# Altium schematic sheet Remote Board.SchDoc("Remote Board")
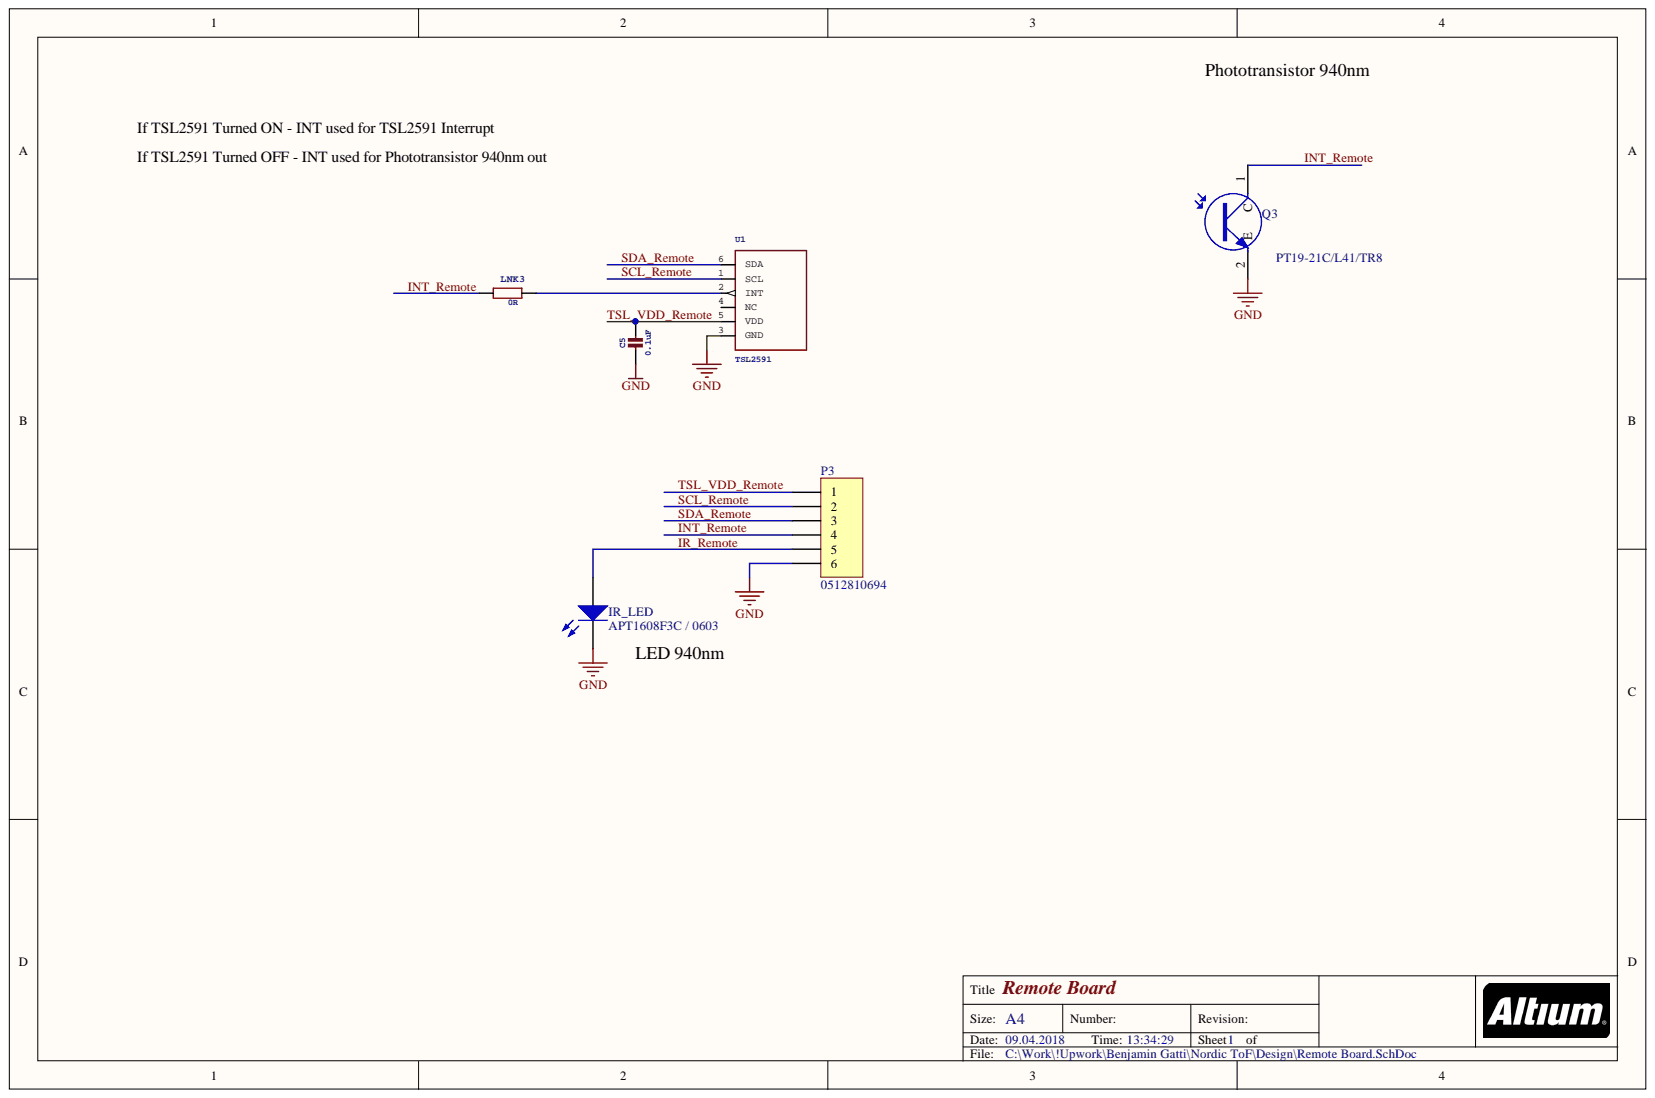
[diagram p0: Remote Board.SchDoc]

## Parts
C5  0.1uF  [CAPC1608X100N]
IR_LED  APT1608F3C / 0603  [LEDC1608X80N_RED]
LNK3  0R  [RESC1608X50N]
P3  0512810694  [0512810694]
Q3  PT19-21C/L41/TR8  [LEDC1608X80N_RED]
U1  TSL2591  [DFN6_TAOS]

## Nets
GND: C5.2 IR_LED.2 P3.6 Q3.2 U1.3(GND)
INT_Remote: LNK3.1 P3.4 Q3.1
IR_Remote: IR_LED.1 P3.5
SCL_Remote: P3.2 U1.1(SCL)
SDA_Remote: P3.3 U1.6(SDA)
TSL_VDD_Remote: C5.1 P3.1 U1.5(VDD)
NetLNK3_2: LNK3.2 U1.2(INT)

Not connected: U1 4(NC)

## Library data of the parts
C5 = CAP_CERAMIC0805-NOOUTLINE: Ceramic Capacitors   For new designs, use the packages preceded by an '_' character since they are more reliable:   The following footprints should be used on most boards: _0402 - Standard footprint for regular board layouts  _0603 - Standard footprint for regular board layouts  _0805 - Standard footprint for regular board layouts  _1206 - Standard footprint for regular board layouts       For extremely tight-pitch boards where space is at a premium, the following 'micro-pitch' footprints can be used (smaller pads, no silkscreen outline, etc.): _0402MP - Micro-pitch footprint for very dense/compact boards  _0603MP - Micro-pitch footprint for very dense/compact boards  _0805MP - Micro-pitch footprint for very dense/compact boards  _1206MP - Micro-pitch footprint for very dense/compact boards; Library Name: microbuilder.IntLib; Component Kind: Standard
IR_LED = LED1: Typical RED GaAs LED; Simulation: LED1; Published: 8-Jun-2000; PackageReference: LED-1; PackageDescription: LED; 2 Leads; Library Name: Miscellaneous Devices.IntLib; LatestRevisionNote: Mech Layer 1 removed.; LatestRevisionDate: 08-Jul-2005; Component Kind: Standard; Publisher: Altium Limited
LNK3 = R-EU_R0402: RESISTOR, European symbol; Manufacturer Part Number: RC0402FR-0710KL; Library Name: rcl.IntLib; Component Kind: Standard
P3 = Header 6: Header, 6-Pin; Signal Integrity: Connector; Library Name: Miscellaneous Connectors.IntLib; LatestRevisionNote: Re-released for DXP Platform.; LatestRevisionDate: 17-Jul-2002; Component Kind: Standard; Publisher: Altium Limited
Q3 = Photo NPN: NPN Phototransistor; Published: 24-Mar-1999; PackageReference: TO-220_A; Library Name: Miscellaneous Devices.IntLib; LatestRevisionNote: Re-released for DXP Platform.; LatestRevisionDate: 17-Jul-2002; Component Kind: Standard; Code_JEDEC: TO-220-AC; Publisher: Altium Limited
U1 = LIGHT_TSL2591: TSL2591 Light-To-Digital Sensor; Library Name: microbuilder.IntLib; Component Kind: Standard
